# Altium schematic sheet DigitalPowerCtrl.SchDoc
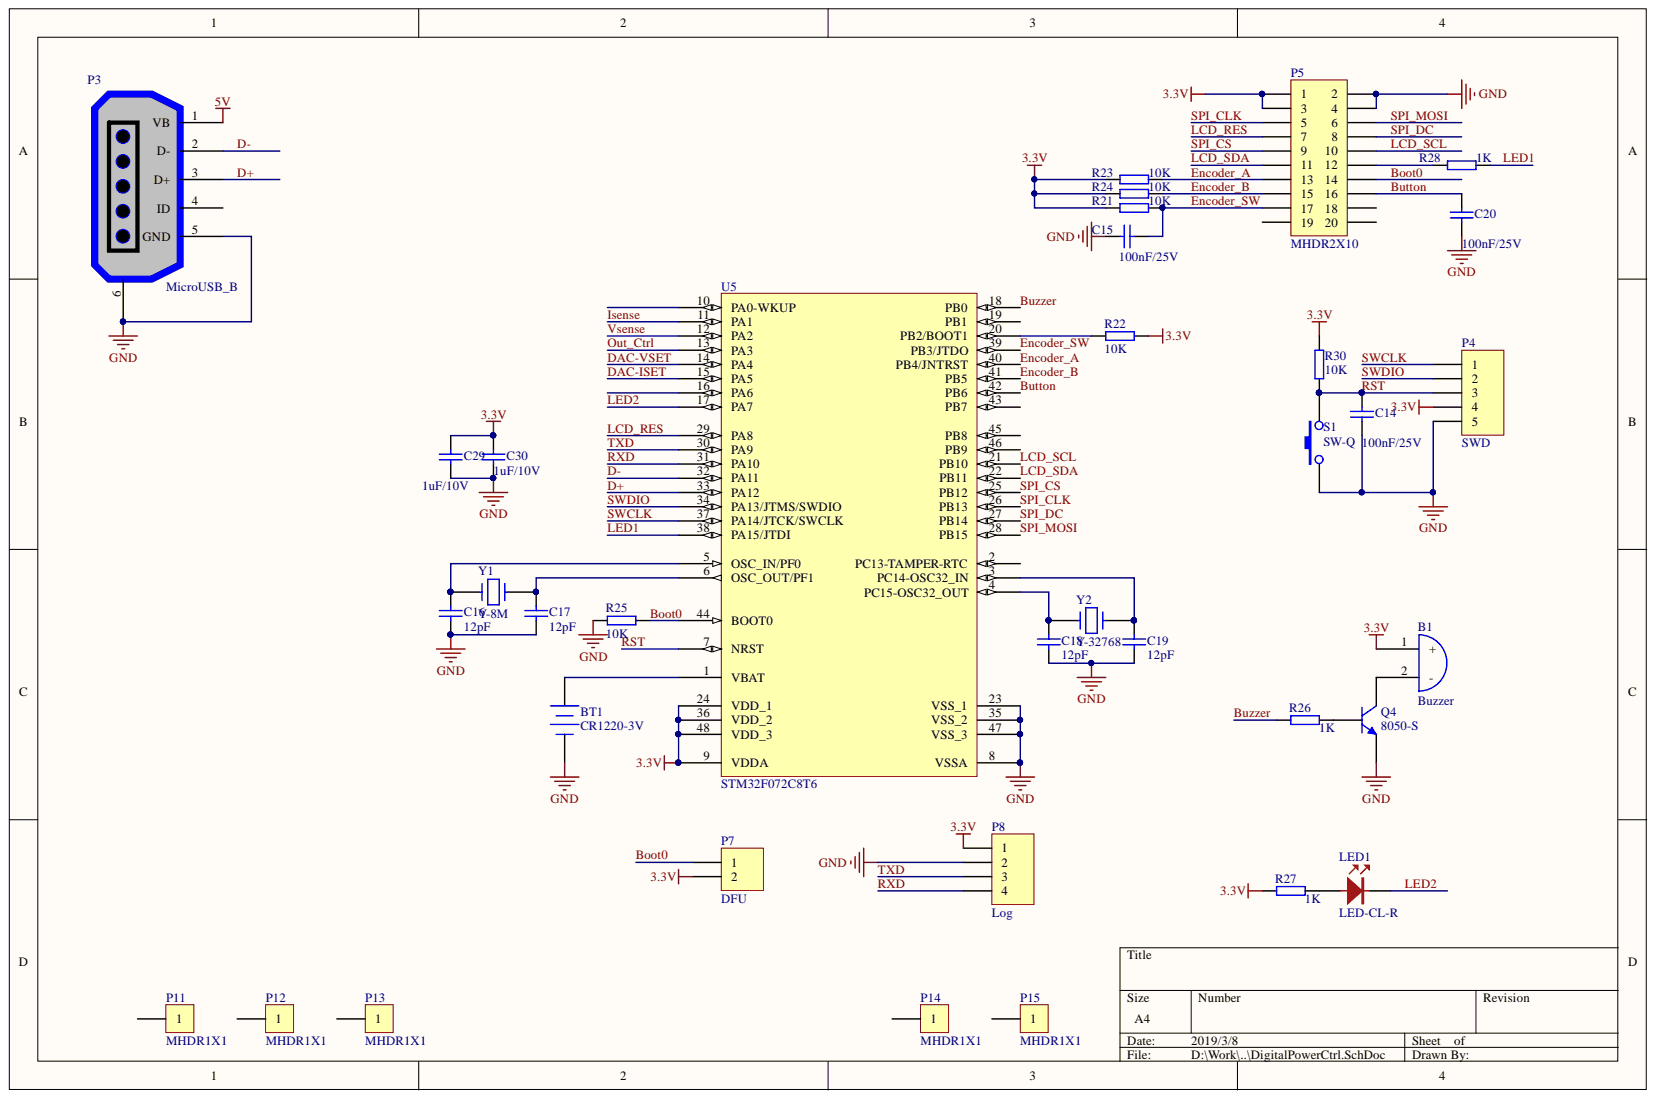
[diagram p0: DigitalPowerCtrl.SchDoc]

## Parts
B1  Buzzer  [HA-12*9.5-2]
BT1  CR1220-3V  [CR1220-3V]
C14  100nF/25V  [0603-C]
C15  100nF/25V  [0603-C]
C16  12pF  [0603-C]
C17  12pF  [0603-C]
C18  12pF  [0603-C]
C19  12pF  [0603-C]
C20  100nF/25V  [0603-C]
C29  1uF/10V  [0603-C]
C30  1uF/10V  [0603-C]
LED1  LED-CL-R  [LED0603-2]
P3  MicroUSB_B  [MicroUSB-B]
P4  SWD  [JP-DV2.54-1*1*5-5]
P5  MHDR2X10  [XSC-W2.54-2*10]
P7  DFU  [JP-DV2.54-1*1*2-5]
P8  Log  [JP-DV2.54-1*1*4-5]
P11  MHDR1X1  [Mark]
P12  MHDR1X1  [Mark]
P13  MHDR1X1  [Mark]
P14  MHDR1X1  [Hole-M3]
P15  MHDR1X1  [Hole-M3]
Q4  8050-S  [SOT-23-3]
R21  10K  [0603-R]
R22  10K  [0603-R]
R23  10K  [0603-R]
R24  10K  [0603-R]
R25  10K  [0603-R]
R26  1K  [0603-R]
R27  1K  [0603-R]
R28  1K  [0603-R]
R30  10K  [0603-R]
S1  SW-Q  [SWQ-DW6*6*4.3-4]
U5  STM32F072C8T6  [QFP-48(9*9-0.5)]
Y1  Y-8M  [SM-49S-2]
Y2  Y-32768  [YC-2*6-2]

## Nets
3.3V: B1.1 C29.2 C30.2 P4.4 P5.1 P5.3 P7.2 P8.1 R21.2 R22.2 R23.1 R24.1 R27.2 R30.2 U5.9(VDDA) U5.24(VDD_1) U5.36(VDD_2) U5.48(VDD_3)
5V: P3.1(VB)
GND: BT1.2 C14.2 C15.2 C16.1 C17.1 C18.1 C19.1 C20.2 C29.1 C30.1 P3.5(GND) P3.6 P4.5 P5.2 P5.4 P8.2 Q4.2 R25.1 S1.1 U5.8(VSSA) U5.23(VSS_1) U5.35(VSS_2) U5.47(VSS_3)
BOOT0: P5.14 P7.1 R25.2 U5.44(BOOT0)
BUTTON: C20.1 P5.16 U5.42(PB6)
BUZZER: R26.2 U5.18(PB0)
D+: P3.3(D+) U5.33(PA12)
D-: P3.2(D-) U5.32(PA11)
DAC-ISET: U5.15(PA5)
DAC-VSET: U5.14(PA4)
ENCODER_A: P5.13 R23.2 U5.40(PB4/JNTRST)
ENCODER_B: P5.15 R24.2 U5.41(PB5)
ENCODER_SW: C15.1 P5.17 R21.1 U5.39(PB3/JTDO)
ISENSE: U5.11(PA1)
LCD_RES: P5.7 U5.29(PA8)
LCD_SCL: P5.10 U5.21(PB10)
LCD_SDA: P5.11 U5.22(PB11)
LED1: R28.1 U5.38(PA15/JTDI)
LED2: LED1.2 U5.17(PA7)
OUT_CTRL: U5.13(PA3)
RST: C14.1 P4.3 R30.1 S1.2 U5.7(NRST)
RXD: P8.4 U5.31(PA10)
SPI_CLK: P5.5 U5.26(PB13)
SPI_CS: P5.9 U5.25(PB12)
SPI_DC: P5.8 U5.27(PB14)
SPI_MOSI: P5.6 U5.28(PB15)
SWCLK: P4.1 U5.37(PA14/JTCK/SWCLK)
SWDIO: P4.2 U5.34(PA13/JTMS/SWDIO)
TXD: P8.3 U5.30(PA9)
VSENSE: U5.12(PA2)
NetB1_2: B1.2 Q4.3
NetBT1_1: BT1.1 U5.1(VBAT)
NetC16_2: C16.2 U5.5(OSC_IN/PF0) Y1.1
NetC17_2: C17.2 U5.6(OSC_OUT/PF1) Y1.2
NetC18_2: C18.2 U5.4(PC15-OSC32_OUT) Y2.1
NetC19_2: C19.2 U5.3(PC14-OSC32_IN) Y2.2
NetLED1_1: LED1.1 R27.1
NetP5_12: P5.12 R28.2
NetQ4_1: Q4.1 R26.1
NetR22_1: R22.1 U5.20(PB2/BOOT1)

Not connected: P3 4(ID); P5 18 19 20; P11 1; P12 1; P13 1; P14 1; P15 1; U5 2(PC13-TAMPER-RTC) 10(PA0-WKUP) 16(PA6) 19(PB1) 43(PB7) 45(PB8) 46(PB9)

## Library data of the parts
B1 = Buzzer: 蜂鸣器; Component Kind: Standard; Library Name: 分立元件杂类.SchLib
BT1 = CR1220-3V: 电池座; Manufacturer: Generic Components; Component Kind: Standard; Library Name: 连接器.SchLib; Published: 1999
C14 C15 C20 = 032074: Database Table Name: 陶瓷电容$; 产品型名: CC0603KRX7R8BB104(C0603-104K/25V); 品名: 电容器; 品牌: YAGEO; 封装: 0603; Component Kind: Standard; 存货编码: 032074; Library Name: LSD.DbLib
C16-C19 = 056652: Database Table Name: 陶瓷电容$; 产品型名: GRM1885C1H120JA01D(C0603-12PF/50V); 品名: 电容器; 品牌: MURATA; 封装: 0603; Component Kind: Standard; 存货编码: 056652; Library Name: LSD.DbLib
C29 C30 = 032075: Database Table Name: 陶瓷电容$; 产品型名: CC0603KRX5R6BB105(C0603-105K/10V); 品名: 电容器; 品牌: YAGEO; 封装: 0603; Component Kind: Standard; 存货编码: 032075; Library Name: LSD.DbLib
LED1 = LED-CL-R: LED红色; Component Kind: Standard; Library Name: 分立元件杂类.SchLib
P3 = MicroUSB_B: Micro USB B; 产品型名: UJ05H20-02HA1RC37B; 品牌: 福大电子; 存货编码: 086806; Component Kind: Standard; Library Name: 连接器.SchLib
P4 = MHDR1X5: Header, 5-Pin; Component Kind: Standard; LatestRevisionDate: 17-Jul-2002; LatestRevisionNote: Re-released for DXP Platform.; Library Name: 连接器.SchLib; Publisher: Altium Limited
P5 = MHDR2X10: Header, 10-Pin, Dual row; Component Kind: Standard; LatestRevisionDate: 17-Jul-2002; LatestRevisionNote: Re-released for DXP Platform.; Library Name: 连接器.SchLib; Publisher: Altium Limited
P7 = MHDR1X2: Header, 2-Pin; Component Kind: Standard; LatestRevisionDate: 17-Jul-2002; LatestRevisionNote: Re-released for DXP Platform.; Library Name: 连接器.SchLib; Publisher: Altium Limited
P8 = MHDR1X4: Header, 4-Pin; Component Kind: Standard; LatestRevisionDate: 17-Jul-2002; LatestRevisionNote: Re-released for DXP Platform.; Library Name: 连接器.SchLib; Publisher: Altium Limited
P11-P15 = MHDR1X1: Header, 1-Pin; Component Kind: Standard; LatestRevisionDate: 17-Jul-2002; LatestRevisionNote: Re-released for DXP Platform.; Library Name: 连接器.SchLib; Publisher: Altium Limited
Q4 = 8050-S: NPN三极管; Manufacturer: Generic Components; Class: Discrete; SubClass: BJT - Low Power; Component Kind: Standard; Published: 08/06/2000; Library Name: 基本分立元件.SchLib; Publisher: Altium Hobart Technology Centre
R21-R25 R30 = 023845: Database Table Name: 贴片电阻$; 产品型名: RJ4D03-10K; 品牌: Uniohm; 封装: 0603; Component Kind: Standard; 存货编码: 023845; Library Name: LSD.DbLib
R26-R28 = 002979: Database Table Name: 贴片电阻$; 产品型名: RJ0603-1K; 品牌: Uniohm; 封装: 0603; Component Kind: Standard; 存货编码: 002979; Library Name: LSD.DbLib
U5 = STM32F072C8T6: STM32 ARM-based 32-bit MCU with 64 Kbytes Flash, 48-pin LQFP, Industrial Temperature; Component Kind: Standard; ComponentLink1Description: Manufacturer Link; ComponentLink1URL: www.st.com/stm32; ComponentLink2Description: Datasheet; ComponentLink2URL: http://www.st.com/stonline/products/literature/ds/13587.pdf; DatasheetVersion: rev6, Mar-2008; PackageDescription: 48-Pin Low-Profile Quad Flat Pack, 7 x 7 mm Body, 0.5 mm Pitch (LQFP48); PackageReference: LQFP48; PackageVersion: Mar-2008; Published: 30-May-2008; Library Name: 单片机.SchLib; Publisher: Altium Limited
Y1 = Y-ECXX-XXM: 无源晶振; Component Kind: Standard; Library Name: 分立元件杂类.SchLib
Y2 = Y-CCXX-XXM: 无源晶振; Component Kind: Standard; Library Name: 分立元件杂类.SchLib
S1 = SW-Q: Component Kind: Standard; Library Name: 分立元件杂类.SchLib
